# Altium schematic sheet [4] Alimentation FPGA.SchDoc("[4] Alimentation FPGA")
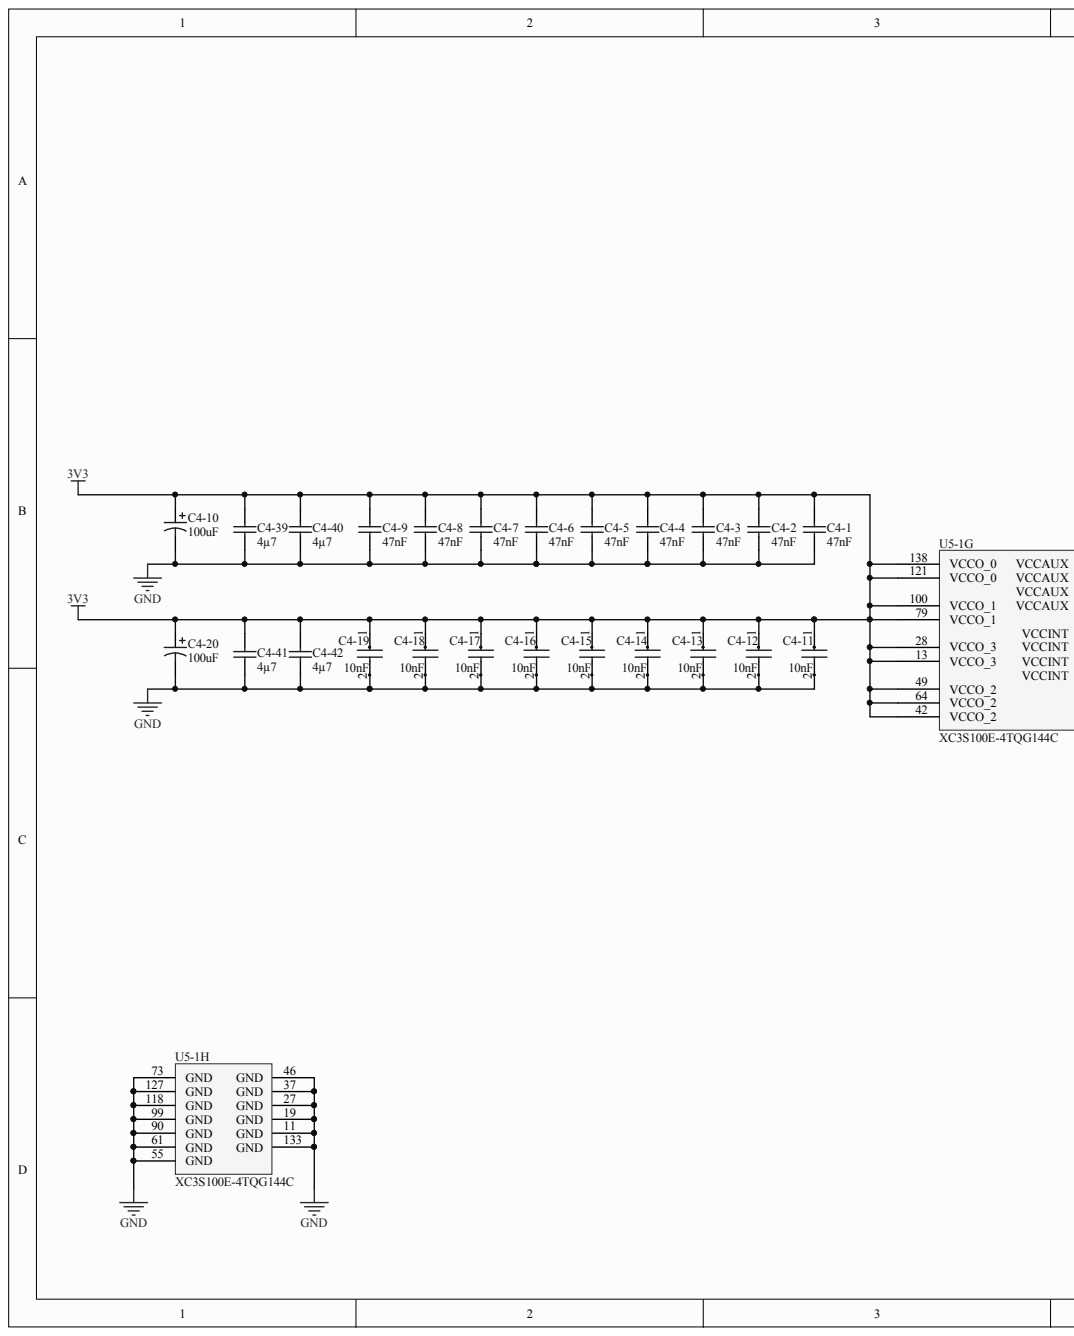
[diagram p0: [4] Alimentation FPGA.SchDoc, left]
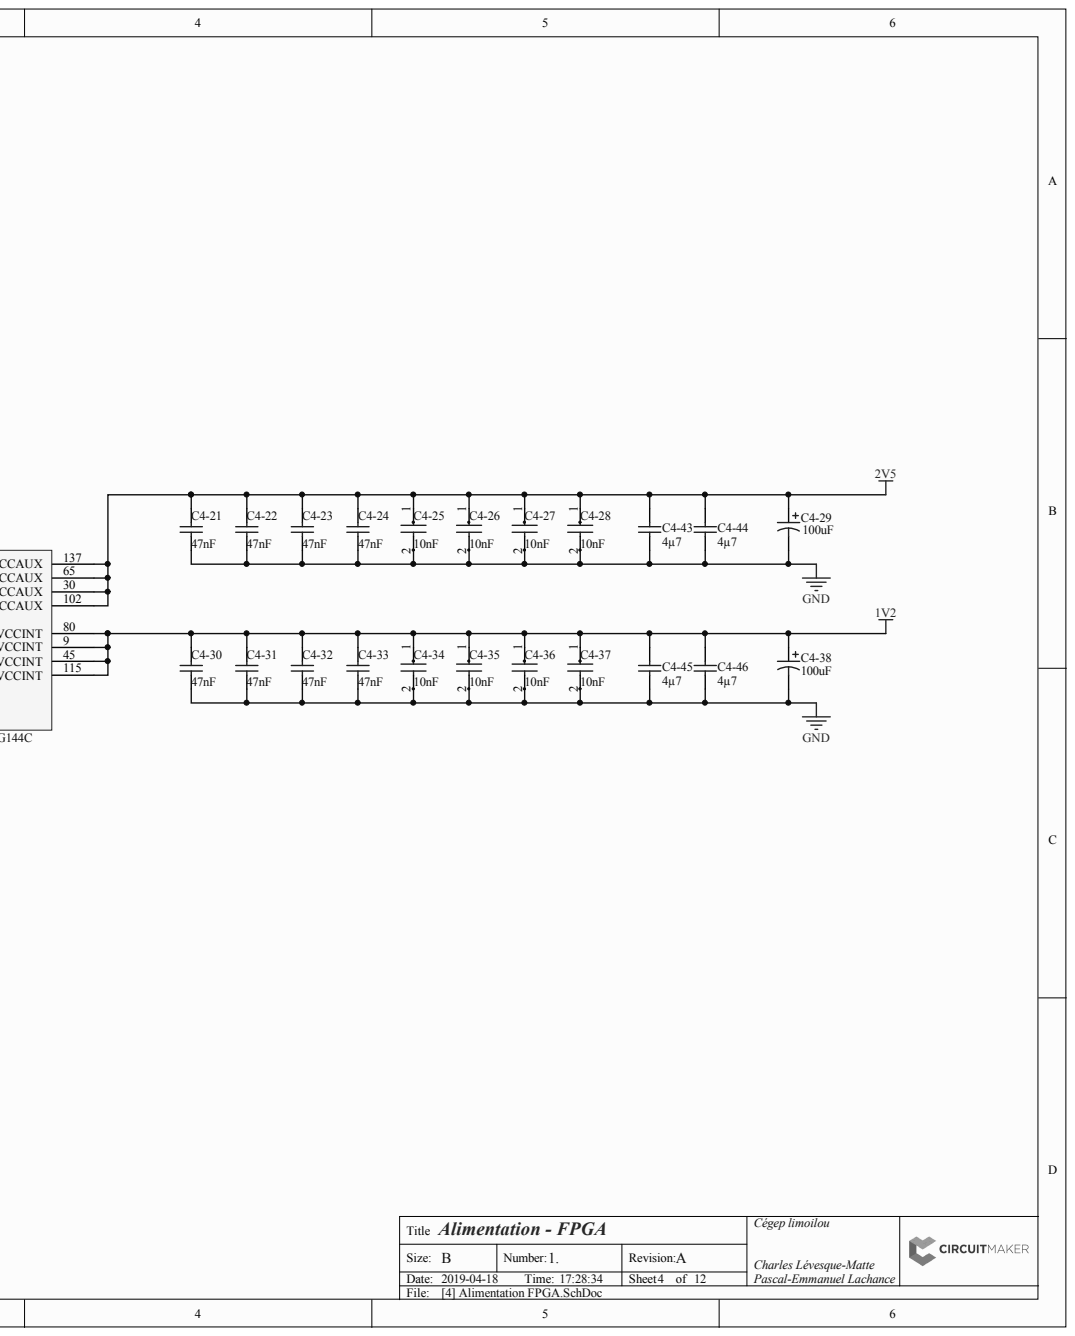
[diagram p1: [4] Alimentation FPGA.SchDoc, right]

## Parts
C4-1  47nF  [PCB-f7g22ikjpewxbvyfmymx-1]
C4-2  47nF  [PCB-f7g22ikjpewxbvyfmymx-1]
C4-3  47nF  [PCB-f7g22ikjpewxbvyfmymx-1]
C4-4  47nF  [PCB-f7g22ikjpewxbvyfmymx-1]
C4-5  47nF  [PCB-f7g22ikjpewxbvyfmymx-1]
C4-6  47nF  [PCB-f7g22ikjpewxbvyfmymx-1]
C4-7  47nF  [PCB-f7g22ikjpewxbvyfmymx-1]
C4-8  47nF  [PCB-f7g22ikjpewxbvyfmymx-1]
C4-9  47nF  [PCB-f7g22ikjpewxbvyfmymx-1]
C4-10  100uF  [C_ALU_C_SIZE]
C4-11  10nF  [C0402_B]
C4-12  10nF  [C0402_B]
C4-13  10nF  [C0402_B]
C4-14  10nF  [C0402_B]
C4-15  10nF  [C0402_B]
C4-16  10nF  [C0402_B]
C4-17  10nF  [C0402_B]
C4-18  10nF  [C0402_B]
C4-19  10nF  [C0402_B]
C4-20  100uF  [C_ALU_C_SIZE]
C4-21  47nF  [PCB-f7g22ikjpewxbvyfmymx-1]
C4-22  47nF  [PCB-f7g22ikjpewxbvyfmymx-1]
C4-23  47nF  [PCB-f7g22ikjpewxbvyfmymx-1]
C4-24  47nF  [PCB-f7g22ikjpewxbvyfmymx-1]
C4-25  10nF  [C0402_B]
C4-26  10nF  [C0402_B]
C4-27  10nF  [C0402_B]
C4-28  10nF  [C0402_B]
C4-29  100uF  [C_ALU_C_SIZE]
C4-30  47nF  [PCB-f7g22ikjpewxbvyfmymx-1]
C4-31  47nF  [PCB-f7g22ikjpewxbvyfmymx-1]
C4-32  47nF  [PCB-f7g22ikjpewxbvyfmymx-1]
C4-33  47nF  [PCB-f7g22ikjpewxbvyfmymx-1]
C4-34  10nF  [C0402_B]
C4-35  10nF  [C0402_B]
C4-36  10nF  [C0402_B]
C4-37  10nF  [C0402_B]
C4-38  100uF  [C_ALU_C_SIZE]
C4-39  4µ7  [PCB-p58ky0zi3k8sjvuu1j9b-1]
C4-40  4µ7  [PCB-p58ky0zi3k8sjvuu1j9b-1]
C4-41  4µ7  [PCB-p58ky0zi3k8sjvuu1j9b-1]
C4-42  4µ7  [PCB-p58ky0zi3k8sjvuu1j9b-1]
C4-43  4µ7  [PCB-p58ky0zi3k8sjvuu1j9b-1]
C4-44  4µ7  [PCB-p58ky0zi3k8sjvuu1j9b-1]
C4-45  4µ7  [PCB-p58ky0zi3k8sjvuu1j9b-1]
C4-46  4µ7  [PCB-p58ky0zi3k8sjvuu1j9b-1]
U5-1  XC3S100E-4TQG144C  [TQG144_L]  (parts G,H)

## Nets
1V2: C4-30.2 C4-31.2 C4-32.2 C4-33.2 C4-34.1 C4-35.1 C4-36.1 C4-37.1 C4-38.1 C4-45.2 C4-46.2 U5-1.9(VCCINT) U5-1.45(VCCINT) U5-1.80(VCCINT) U5-1.115(VCCINT)
2V5: C4-21.2 C4-22.2 C4-23.2 C4-24.2 C4-25.1 C4-26.1 C4-27.1 C4-28.1 C4-29.1 C4-43.2 C4-44.2 U5-1.30(VCCAUX) U5-1.65(VCCAUX) U5-1.102(VCCAUX) U5-1.137(VCCAUX)
3V3: C4-1.2 C4-2.2 C4-3.2 C4-4.2 C4-5.2 C4-6.2 C4-7.2 C4-8.2 C4-9.2 C4-10.1 C4-11.1 C4-12.1 C4-13.1 C4-14.1 C4-15.1 C4-16.1 C4-17.1 C4-18.1 C4-19.1 C4-20.1 C4-39.2 C4-40.2 C4-41.2 C4-42.2 U5-1.13(VCCO_3) U5-1.28(VCCO_3) U5-1.42(VCCO_2) U5-1.49(VCCO_2) U5-1.64(VCCO_2) U5-1.79(VCCO_1) U5-1.100(VCCO_1) U5-1.121(VCCO_0) U5-1.138(VCCO_0)
GND: C4-1.1 C4-2.1 C4-3.1 C4-4.1 C4-5.1 C4-6.1 C4-7.1 C4-8.1 C4-9.1 C4-10.2 C4-11.2 C4-12.2 C4-13.2 C4-14.2 C4-15.2 C4-16.2 C4-17.2 C4-18.2 C4-19.2 C4-20.2 C4-21.1 C4-22.1 C4-23.1 C4-24.1 C4-25.2 C4-26.2 C4-27.2 C4-28.2 C4-29.2 C4-30.1 C4-31.1 C4-32.1 C4-33.1 C4-34.2 C4-35.2 C4-36.2 C4-37.2 C4-38.2 C4-39.1 C4-40.1 C4-41.1 C4-42.1 C4-43.1 C4-44.1 C4-45.1 C4-46.1 U5-1.11(GND) U5-1.19(GND) U5-1.27(GND) U5-1.37(GND) U5-1.46(GND) U5-1.55(GND) U5-1.61(GND) U5-1.73(GND) U5-1.90(GND) U5-1.99(GND) U5-1.118(GND) U5-1.127(GND) U5-1.133(GND)

## Library data of the parts
C4-1-C4-9 C4-21-C4-24 C4-30-C4-33 = CMP-4a2082a1800295e7-1: CGA Series 0402 47 nF 50 V ±10 % Tolerance X7R SMT Multilayer Ceramic Capacitor; Manufacturer Part Number: CGA2B3X7R1H473K050BB; Capacitance: 47.0 nF; Capacitance Tolerance: ±10%; Lead-Free Status: Lead Free; Voltage Rating (DC): 50.0 V; Case/Package: 0402; Component Kind: Standard; Dielectric Characteristic: X7R; Library Name: FavoritesLibrary; Mounting Style: Surface Mount; OctopartID: 4a2082a1800295e7; Octopart URL: https://octopart.com/cga2b3x7r1h473k050bb-tdk-25947972; Operating Temperature: -55.0 °C to 125 °C; Packaging: Cut Tape (CT), Reel, Tape & Reel (TR); REACH SVHC Compliance: No SVHC; RoHS: Compliant
C4-10 C4-20 C4-29 C4-38 = CMP-6d95a6c5262fb252-2: Capacitor; Alum Electrolytic; Cap 100 uF; Tol 20%; Vol-Rtg 10 VDC; SMT; 5x5.5; Cut Tape; Component Kind: Standard; Library Name: CommunityVault
C4-11-C4-19 C4-25-C4-28 C4-34-C4-37 = CMP-a9f260875c557635-1: Manufacturer Part Number: CC0402KRX7R9BB103; Capacitance: 1e-08 F; Capacitance Tolerance: ±10%; Dielectric Characteristic: X7R; Lead-Free Status: Lead Free; Voltage Rating (DC): 50.0 V; Case/Package: 0402; Component Kind: Standard; Halogen Free Status: Halogen Free; Library Name: CommunityVault; Mounting Style: Surface Mount; Number of Pins: 2; OctopartID: a9f260875c557635; Octopart Url: http://octopart.com/cc0402krx7r9bb103-yageo-8376513; Packaging: Cut Tape (CT); RoHS: Compliant; Seller Landing  Url: https://octopart-clicks.com/click/track?country=US&ak=8157f166&sig=0dbcab8&sid=5489&ppid=8376513&vpid=47199913&ct=offers
C4-39-C4-46 = CMP-e7282a5831d738ef-2: 0402 10 V 4.7 uF ±20% Tolerance X5R Multilayer Ceramic Chip Capacitor; Manufacturer Part Number: GRM155R61A475MEAAD; Capacitance: 4.70 µF; Voltage Rating (DC): 10.0 V; Capacitance Tolerance: ±20%; Case/Package: 0402; Component Kind: Standard; Dielectric Characteristic: X5R; OctopartID: e7282a5831d738ef; Octopart URL: https://octopart.com/grm155r61a475meaad-murata-75166617; Operating Temperature: -55.0 °C (min); Packaging: Cut Tape (CT); RoHS: Compliant; Size-Length: 1.00 mm; Size-Thickness: 500 µm; Size-Width: 500 µm
  C4-39-C4-42: Library Name: CommunityVault
  C4-43-C4-46: Library Name: FavoritesLibrary
U5-1 = CMP-971465-2: Spartan-3E 1.2V FPGA, 108 User I/Os, 144-Pin TQFP, Standard Performance, Commercial Grade, Pb-Free; Lead-Free Status: Lead Free; Number of Gates: 100000; Number of I/O Pins: 108; RAM Memory Size: 9216 B; Case/Package: TQFP; Component Kind: Standard; Library Name: CommunityVault; Manufacturer Part Number: XC3S100E-4TQG144C; Mounting Style: Surface Mount; Number of Pins: 144; OctopartID: 2992e09f0182f655; Octopart Url: https://octopart.com/xc3s100e-4tqg144c-xilinx-462198; Packaging: Tray; RoHS: Compliant; Supply Voltage (DC): 1.2 V
